# Altium schematic sheet MASTER.SchDoc
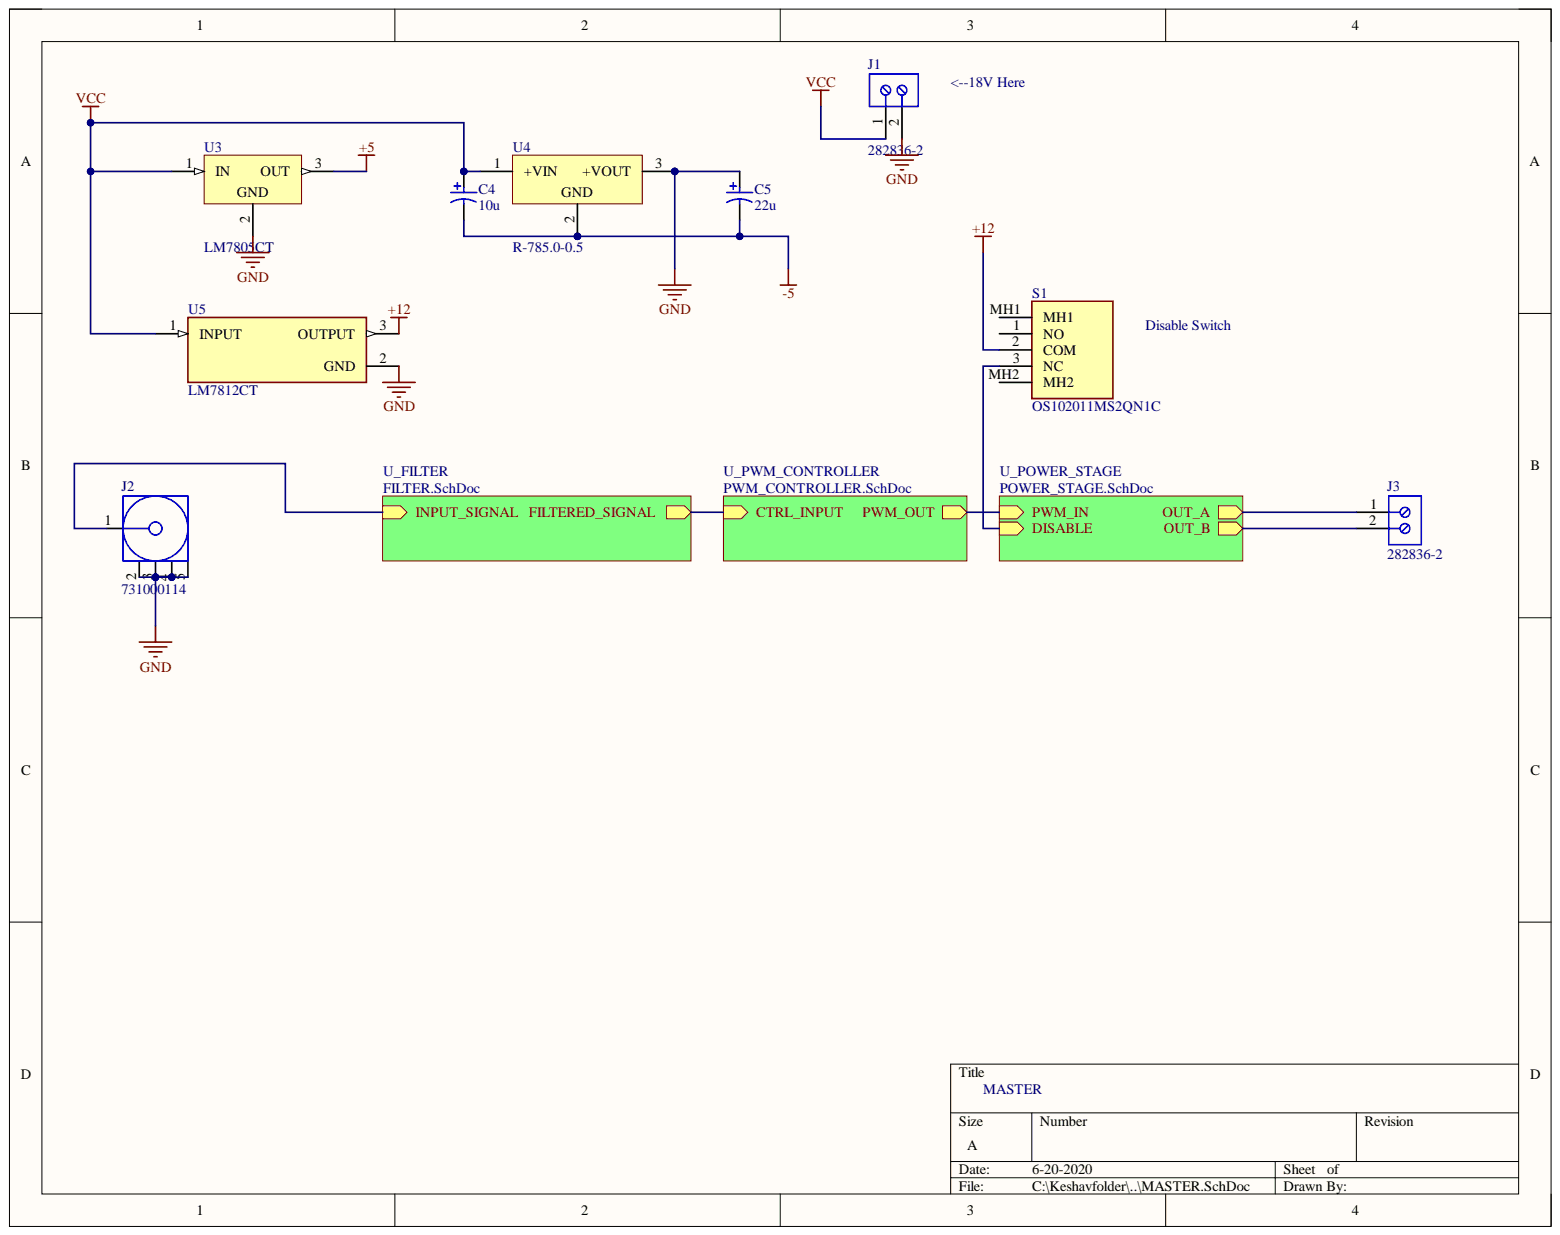
[diagram p0: MASTER.SchDoc]

## Parts
C4  10u  [PNSC-ECA-1VM221-2]
C5  22u  [PNSC-ECA-1VM221-2]
J1  282836-2  [TECO-282836-2_V]
J2  731000114  [MOLX-731000114_V]
J3  282836-2  [TECO-282836-2_V]
S1  OS102011MS2QN1C  [OS102011MS2QN1C]
U3  LM7805CT  [FAIR-TO-220-3]
U4  R-785.0-0.5  [REPO-R-78XX-3_V]
U5  LM7812CT  [FP-TO220B03-MFG]

## Nets
+5: U3.3
+12: S1.2(COM) U5.3
-5: C4.2 C5.2 U4.2
GND: C5.1 J1.2 J2.2 J2.3 J2.4 J2.5 U3.2 U4.3 U5.2
VCC: C4.1 J1.1 U3.1 U4.1 U5.1
NetJ2_1: J2.1
NetJ3_1: J3.1
NetJ3_2: J3.2
NetS1_1: S1.1(NO)
NetS1_3: S1.3(NC)
NetS1_MH1: S1.MH1
NetS1_MH2: S1.MH2
CTRL_INPUT:
PWM_IN:

## Library data of the parts
C4 C5 = CMP-2000-05311-1: Aluminum Electrolytic Capacitor, 220 uF, +/- 20%, 35 V, -40 to 85 degC, 2-Pin THD, RoHS, Bulk; Manufacturer: Panasonic; Capacitance: 220µF; Lifetime@Temperature: 2000Hrs@85degC; Rated Voltage: 35V; Ripple Current: 350mA; Type: Radial; Case Size/Dimension: Ø8x11.5mm; Component Kind: Standard; Datasheet URL: https://datasheet.ciiva.com/26341/441851-da-01-en-elko-eca1vm221-m-r-220myf-35v-85c-26341906.pdf; Datasheet Version: Mar-06; Library Name: Altium Content Vault; Manufacturer URL: http://www.panasonic.com/; Operating Temp Range: -40to+85degC; Operating Temp-Max: 85degC; Package Description: 2-Pin Axial Lead, Dia 8 mm, Body Length 12.5 mm; Package Reference: ECA-1VM221; Series: M
J1 J3 = CMP-1684-00017-1: Female Header, Pitch 5 mm, 1 x 2 Position, Height 10 mm, Tail Length 3.5 mm, Rohs, Bulk; Manufacturer: TE Connectivity; 3D Model: 282836-2.pdf; Clamp Material - Plating: Brass-NickelPlated; Current: 13.5A; Dynamic Catalog: BuchananSeries; Featured Product: EurostyleTerminalBlockConnectors; Mating Orientation: HorizontalwithBoard; Number of Levels: 1; Other Names: 5ULV-02B  A98076; Pitch: 0.197"(5.00mm); Positions Per Level: 2; Product Training Modules: Wire-to-BoardEurostyleTerminalBlocks; Screw Thread: M3; Torque - Screw: 0.68Nm(6Lb-In); Voltage: 300V; Wire Gauge: 16-30AWG; Wire Termination: Screw-RisingCageClamp; Category: Connectors,Interconnects; Color: Green; Component Kind: Standard; Contact Material - Plating: Brass-TinPlated; Datasheet URL: http://www.te.com.cn/commerce/DocumentDelivery/DDEController?Action=showdoc&DocId=Catalog+Section%7F1308389_EUROSTYLE_TERMINAL_BLOCKS%7F0607%7Fpdf%7FEnglish%7FENG_CS_1308389_EUROSTYLE_TERMINAL_BLOCKS_0607.pdf%7F282836-2; Family: TerminalBlocks-WiretoBoard; Features: Interlocking(Side); Housing Material: Polyamide(PA66),Nylon6/6; Library Name: Altium Content Vault; Manufacturer URL: http://www.te.com; Mounting Type: ThroughHole; Package Description: Female Header, Pitch 5 mm, 1 x 2 Position, Height 10 mm, Tail Length 3.5 mm; Package Reference: THD; Packaging: Bulk; RoHS Information: 282836-2StatementofCompliance; Screw Material - Plating: Brass-NickelPlated; Series: Buchanan; Standard Package: 300
J2 = CMP-2000-05487-1: SMA Right-Angle PCB Jack Receptacle, Brass Body, Gold Plated, 50 Ohm, 5-Pin THD, RoHS, Tray; Manufacturer: Molex; Component Kind: Standard; Datasheet URL: http://www.molex.com/webdocs/datasheets/pdf/en-us/0731000114_RF_COAX_CONNECTORS.pdf; Datasheet Version: 09/2016; Library Name: Altium Content Vault; Manufacturer URL: http://www.molex.com/; Mounting Technology: ThroughHole; Package Description: 5-Pin Through Hole Device, Body 14.8 x 6.35 mm, Pitch 2.54 mm; Package Reference: 731000114; Package URL: http://www.molex.com/pdm_docs/sd/731000114_sd.pdf
S1 = OS102011MS2QN1C: Switch; Manufacturer_Name: C & K COMPONENTS; Arrow Part Number: OS102011MS2QN1C; Component Kind: Standard; Datasheet Link: http://uk.rs-online.com/web/p/products/1546147; Height: 8.7mm; Manufacturer_Part_Number: OS102011MS2QN1C; Mouser Part Number: 611-OS102011MS2QN1C; Mouser Price/Stock: https://www.mouser.co.uk/ProductDetail/CK/OS102011MS2QN1C?qs=FgXbtR4Gg7KP4hs8ueQ7Wg%3D%3D
U3 = CMP-2000-04938-1: Positive Voltage Regulator, 5 V, 1 A, -40 to 125 degC, 3-Pin TO-220, RoHS, Tube; Manufacturer: FairchildSemiconductor; Accuracy: ±4%; Line Regulation: 100mV; Maximum Input Voltage: 35V; Maximum Output Current: 2.2(Typ)A; Maximum Quiescent Current: 8mA; Number of Outputs: 1; Output Type: Fixed; Output Voltage: 5V; Rad Hard: No; Typical Dropout Voltage @ Current: 2@1AV; Category: LinearRegulator; Component Kind: Standard; Datasheet URL: https://datasheet.ciiva.com/26904/lm7805ct-26904988.pdf; Datasheet Version: Aug-12; Library Name: Altium Content Vault; Load Regulation: 100mV; Manufacturer URL: https://www.fairchildsemi.com/; Mounting: ThroughHole; Operating Temperature: -40to125degC; Package: 3TO-220AB; Package Description: 3-Pin Through Hole Device, Body 10 x 4.5 mm, Pitch 2.54 mm; Package Reference: TO-220; Package URL: https://www.fairchildsemi.com/package-drawings/TO/TO220B03.pdf; Packaging: Rail/Tube; Polarity: Positive; Type: Linear
U4 = CMP-2000-05328-1: DC/DC-Converter, 5 V Single Output, 500 mA, -40 to 85 degC, 3-Pin THD, RoHS, Tube; Manufacturer: Recom Power; Form Factor: 11.5x7.6x14.3mm; Input Voltage: 6.5to34V; Isolation: NonIsolated; Output Voltage: 5V; Component Kind: Standard; Datasheet URL: https://datasheet.ciiva.com/29153/154483-da-01-en-dc-dc-wandler-innoline-r-785-0-0-5-sip3-29153550.pdf; Datasheet Version: Mar-06; Library Name: Altium Content Vault; Manufacturer URL: http://www.recom-power.com/us/americas/home.html; No of Outputs: Single; Type: Encapsulated
U5 = CMP-07128-000015-1: IC REG LINEAR 12V 1A TO220-3; Manufacturer: ON Semiconductor; Obsolete Part: true; Accuracy Percentage: 4%; Dropout Voltage: 2V; Max Supply Voltage: 35V; Nominal Supply Current: 5.1mA; Number Of Outputs: 1; Output Type: Fixed; Standoff Height: 2.7mm; Automotive: No; Category: IC; Component Kind: Standard; ComponentLink2Description: Datasheet; ComponentLink2URL: https://www.mouser.in/datasheet/2/308/LM7812-1125088.pdf; Device Class L1: Integrated Circuits (ICs); Device Class L2: Power Management ICs; Device Class L3: Voltage Regulators - Linear; Digikey Description: IC REG LINEAR 12V 1A TO220-3; Height: 20.4mm; Ipc Land Pattern Name: TO-220; Lead Free: Yes; Library Name: Altium Content Vault; Manufacturer Part Number: LM7812CT; Octopart: https://octopart.com/lm7812ct-on+semiconductor-14383031; Output Current: 1A; Output Voltage: 12V; Package: TO220B03; RoHS: TRUE; Temperature: -40°C to +125°C; Temperature Range High: +125°C; Temperature Range Low: -40°C
